# Altium schematic sheet Micro.SchDoc(Micro)
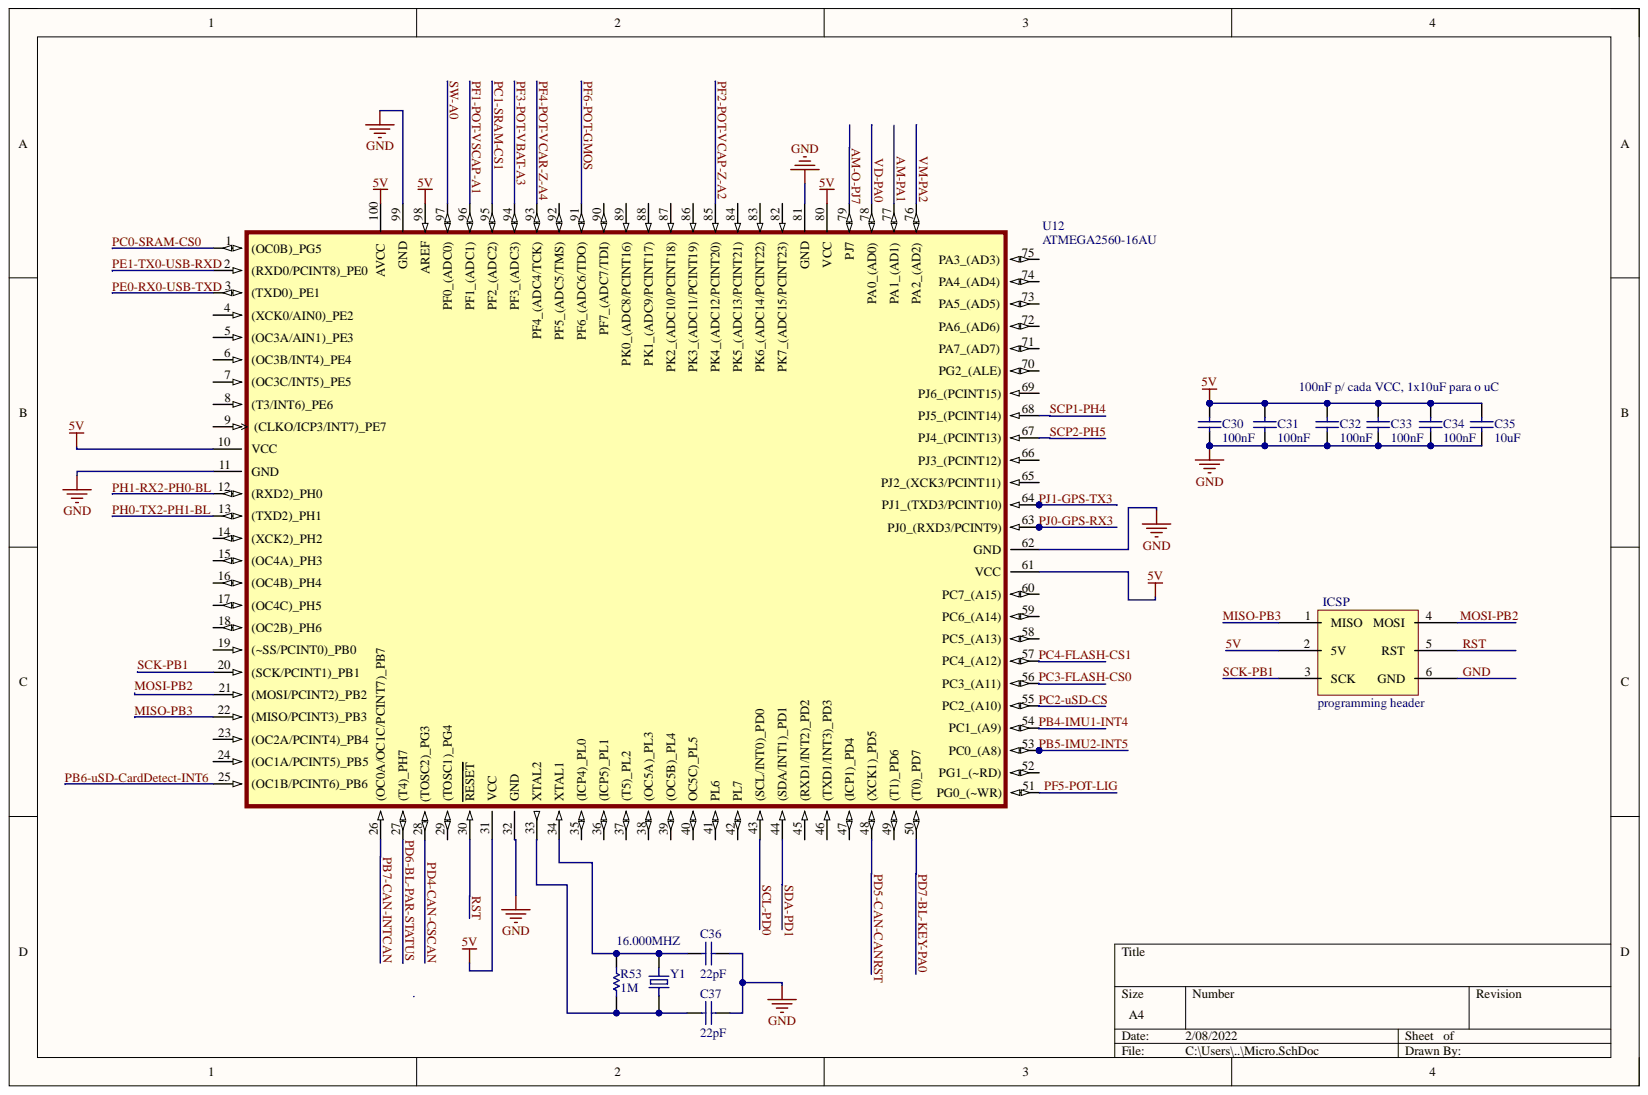
[diagram p0: Micro.SchDoc]

## Parts
C30  100nF  [CAPC2012X65N]
C31  100nF  [CAPC2012X65N]
C32  100nF  [CAPC2012X65N]
C33  100nF  [CAPC2012X65N]
C34  100nF  [CAPC2012X65N]
C35  10uF  value 100nF  [CAPC2012X65N]
C36  22pF  [CAPC2012X65N]
C37  22pF  [CAPC2012X65N]
ICSP  programming header  [HDR2X3]
R53  1M  [RESC2012X65N]
U12  ATMEGA2560-16AU  [QFP50P1600X1600X120-100N]
Y1  16.000MHZ  [XTAL_ABLS-20.000MHZ-B4-T]

## Nets
5V: C30.1 C31.1 C32.1 C33.1 C34.1 C35.1 ICSP.2(5V) U12.10(VCC) U12.31(VCC) U12.61(VCC) U12.80(VCC) U12.98(AREF) U12.100(AVCC)
AM-O-PJ7: U12.79(PJ7)
AM-PA1: U12.77(PA1_(AD1))
GND: C30.2 C31.2 C32.2 C33.2 C34.2 C35.2 C36.2 C37.2 ICSP.6(GND) U12.11(GND) U12.32(GND) U12.62(GND) U12.81(GND) U12.99(GND)
MISO-PB3: ICSP.1(MISO) U12.22((MISO/PCINT3)_PB3)
MOSI-PB2: ICSP.4(MOSI) U12.21((MOSI/PCINT2)_PB2)
PB4-IMU1-INT4: U12.54(PC1_(A9))
PB5-IMU2-INT5: U12.53(PC0_(A8))
PB6-uSD-CardDetect-INT6: U12.25((OC1B/PCINT6)_PB6)
PB7-CAN-INTCAN: U12.26((OC0A/OC1C/PCINT7)_PB7)
PC0-SRAM-CS0: U12.1((OC0B)_PG5)
PC1-SRAM-CS1: U12.95(PF2_(ADC2))
PC2-uSD-CS: U12.55(PC2_(A10))
PC3-FLASH-CS0: U12.56(PC3_(A11))
PC4-FLASH-CS1: U12.57(PC4_(A12))
PD4-CAN-CSCAN: U12.28((TOSC2)_PG3)
PD5-CAN-CANRST: U12.48((XCK1)_PD5)
PD6-BL-PAR-STATUS: U12.27((T4)_PH7)
PD7-BL-KEY-PA0: U12.50((T0)_PD7)
PE0-RX0-USB-TXD: U12.3((TXD0)_PE1)
PE1-TX0-USB-RXD: U12.2((RXD0/PCINT8)_PE0)
PF1-POT-VSCAP-A1: U12.96(PF1_(ADC1))
PF2-POT-VCAP-Z-A2: U12.85(PK4_(ADC12/PCINT20))
PF3-POT-VBAT-A3: U12.94(PF3_(ADC3))
PF4-POT-VCAR-Z-A4: U12.93(PF4_(ADC4/TCK))
PF5-POT-LIG: U12.51(PG0_(~WR))
PF6-POT-GMOS: U12.91(PF6_(ADC6/TDO))
PH0-TX2-PH1-BL: U12.13((TXD2)_PH1)
PH1-RX2-PH0-BL: U12.12((RXD2)_PH0)
PJ0-GPS-RX3: U12.63(PJ0_(RXD3/PCINT9))
PJ1-GPS-TX3: U12.64(PJ1_(TXD3/PCINT10))
RST: ICSP.5(RST) U12.30(RESET)
SCK-PB1: ICSP.3(SCK) U12.20((SCK/PCINT1)_PB1)
SCL-PD0: U12.43((SCL/INT0)_PD0)
SCP1-PH4: U12.68(PJ5_(PCINT14))
SCP2-PH5: U12.67(PJ4_(PCINT13))
SDA-PD1: U12.44((SDA/INT1)_PD1)
SW-A0: U12.97(PF0_(ADC0))
VD-PA0: U12.78(PA0_(AD0))
VM-PA2: U12.76(PA2_(AD2))
NetC36_1: C36.1 R53.1 U12.34(XTAL1) Y1.2
NetC37_1: C37.1 R53.2 U12.33(XTAL2) Y1.1

Not connected: U12 4((XCK0/AIN0)_PE2) 5((OC3A/AIN1)_PE3) 6((OC3B/INT4)_PE4) 7((OC3C/INT5)_PE5) 8((T3/INT6)_PE6) 9((CLKO/ICP3/INT7)_PE7) 14((XCK2)_PH2) 15((OC4A)_PH3) 16((OC4B)_PH4) 17((OC4C)_PH5) 18((OC2B)_PH6) 19((~SS/PCINT0)_PB0) 23((OC2A/PCINT4)_PB4) 24((OC1A/PCINT5)_PB5) 29((TOSC1)_PG4) 35((ICP4)_PL0) 36((ICP5)_PL1) 37((T5)_PL2) 38((OC5A)_PL3) 39((OC5B)_PL4) 40(OC5C)_PL5) 41(PL6) 42(PL7) 45((RXD1/INT2)_PD2) 46((TXD1/INT3)_PD3) 47((ICP1)_PD4) 49((T1)_PD6) 52(PG1_(~RD)) 58(PC5_(A13)) 59(PC6_(A14)) 60(PC7_(A15)) 65(PJ2_(XCK3/PCINT11)) 66(PJ3_(PCINT12)) 69(PJ6_(PCINT15)) 70(PG2_(ALE)) 71(PA7_(AD7)) 72(PA6_(AD6)) 73(PA5_(AD5)) 74(PA4_(AD4)) 75(PA3_(AD3)) 82(PK7_(ADC15/PCINT23)) 83(PK6_(ADC14/PCINT22)) 84(PK5_(ADC13/PCINT21)) 86(PK3_(ADC11/PCINT19)) 87(PK2_(ADC10/PCINT18)) 88(PK1_(ADC9/PCINT17)) 89(PK0_(ADC8/PCINT16)) 90(PF7_(ADC7/TDI)) 92(PF5_(ADC5/TMS))

## Library data of the parts
C30-C35 = Cap: Capacitor; Component Kind: Standard; LatestRevisionDate: 17-Jul-2002; LatestRevisionNote: Re-released for DXP Platform.; Library Name: Miscellaneous Devices.IntLib; PackageDescription: Radial Cap, Thru-Hole; 2 Leads; 0.3 in Pin Spacing; PackageReference: RAD-0.3; Published: 8-Jun-2000; Publisher: Altium Limited
  C30-C34: Value: 100nF
C36 C37 = Cap: Capacitor; Value: 100nF; Component Kind: Standard; LatestRevisionDate: 17-Jul-2002; LatestRevisionNote: Re-released for DXP Platform.; Library Name: Miscellaneous Devices.IntLib; PackageDescription: Radial Cap, Thru-Hole; 2 Leads; 0.3 in Pin Spacing; PackageReference: RAD-0.3; Published: 8-Jun-2000; Publisher: Altium Limited
ICSP = ICSP HEADER: Component Kind: Standard; Library Name: ICSP.SchLib
R53 = Res1: Resistor; Value: 47K; Component Kind: Standard; LatestRevisionDate: 17-Jul-2002; LatestRevisionNote: Re-released for DXP Platform.; Library Name: Miscellaneous Devices.IntLib; PackageDescription: Axial Device, Thru-Hole; 2 Leads; 0.3 in Pin Spacing; PackageReference: AXIAL-0.3; Published: 8-Jun-2000; Signal Integrity: Res; Simulation: RESISTOR; Publisher: Altium Limited
U12 = ATMEGA2560-16AU: IC MCU 8BIT 256KB FLASH 100TQFP; MF: Microchip Technology; Availability: Unavailable; Component Kind: Standard; DigiKey-Purchase-URL: https://snapeda.com/shop?store=DigiKey&id=4797165; Ibis Model: atmega2560_50_tqfp100; Library Name: ATMega2560-16AU.SchLib; MP: ATMEGA2560-16AU; Package: None; Price: None; SnapEDA_Link: https://www.snapeda.com/parts/ATMEGA2560-16AU/Atmel/view-part/4797165/?ref=snap
Y1 = ABLS-20.000MHZ-B4-T: 20MHz Â±30ppm Crystal 18pF 40 Ohms HC-49/US; MF: Abracon LLC; Availability: Unavailable; Component Kind: Standard; MP: ABLS-20.000MHZ-B4-T; Package: HC-49/US Abracon LLC; Price: None; SnapEDA_Link: https://www.snapeda.com/parts/ABLS-20.000MHZ-B4-T/Abracon%20LLC/view-part/383704/?ref=snap; Library Name: Resonador16Mhz.SchLib
